# Altium schematic sheet PAGE3_POWER.SchDoc
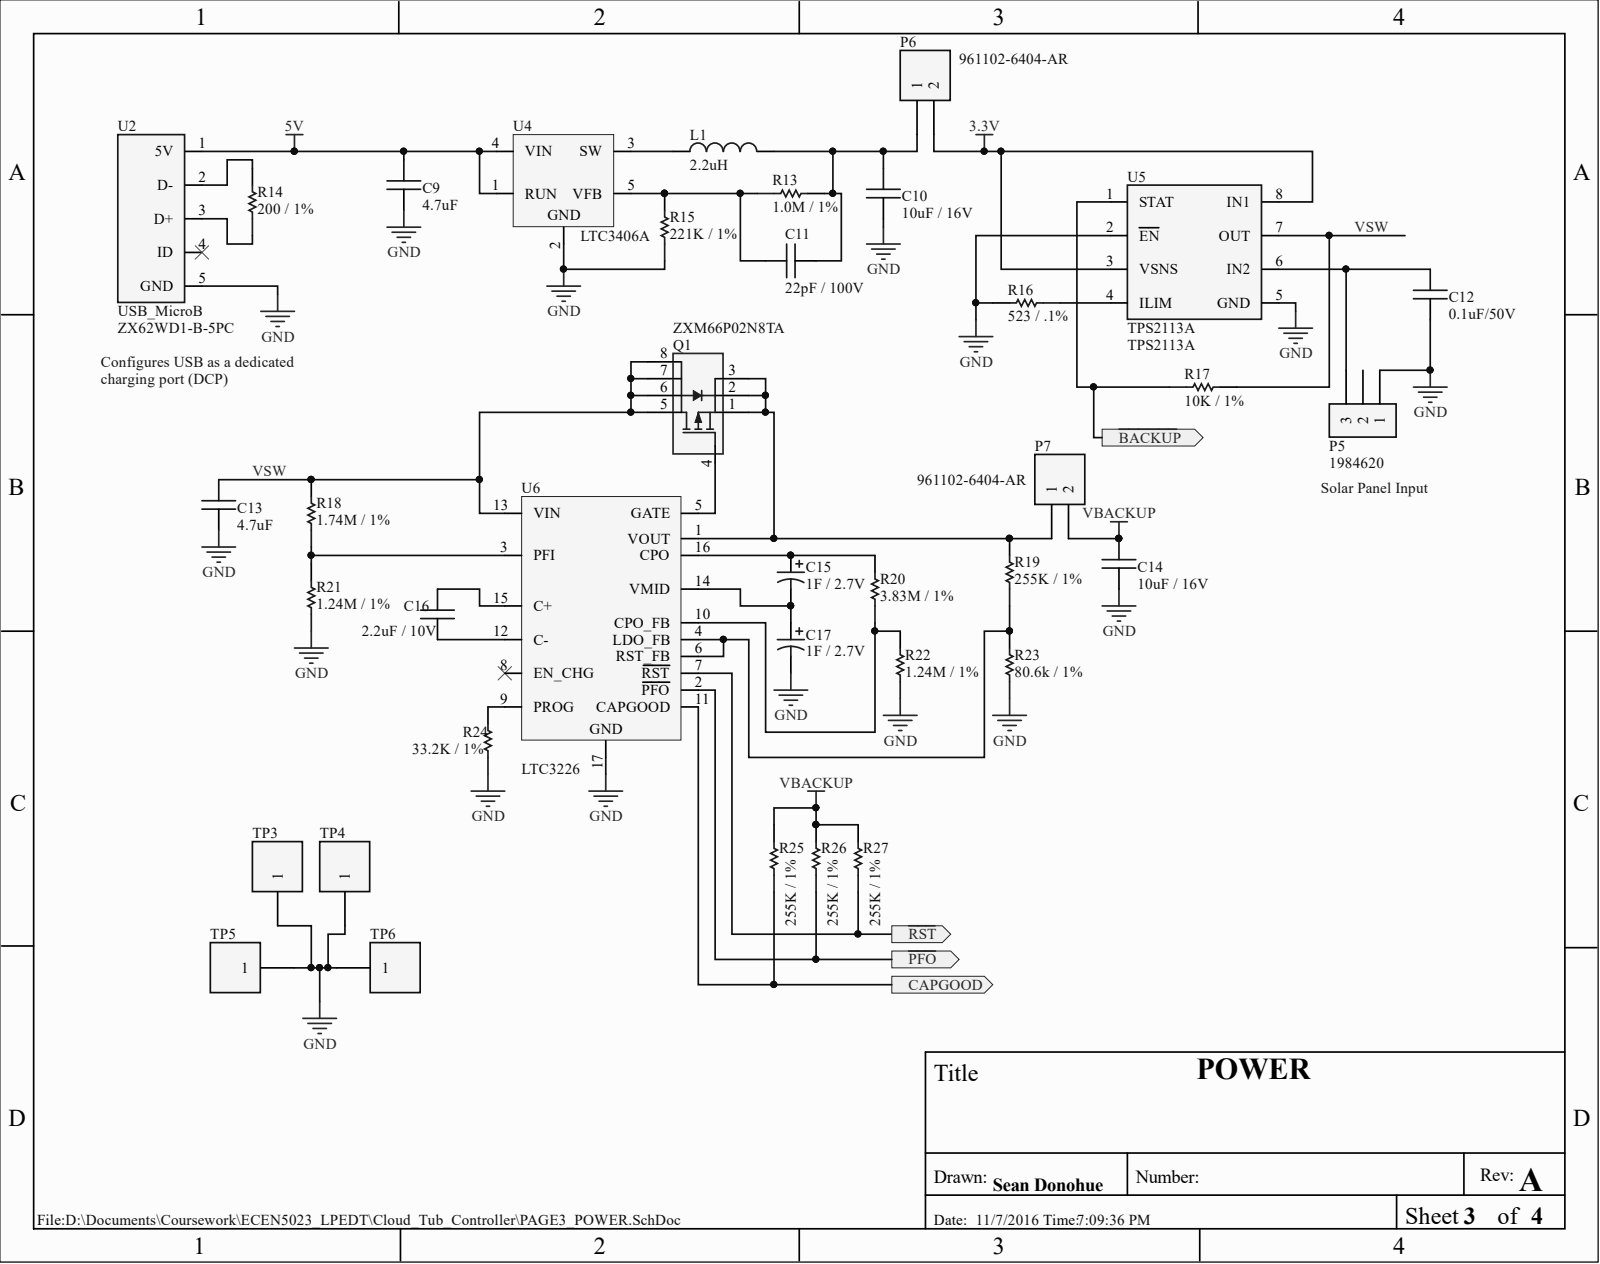
[diagram p0: PAGE3_POWER.SchDoc]

## Parts
C9  value 4.7uF  [0805]  (hidden comment: cap)
C10  value 10uF / 16V  [0603]  (hidden comment: cap)
C11  value 22pF / 100V  [0603]  (hidden comment: cap)
C12  value 0.1uF/50V  [0603]  (hidden comment: cap)
C13  value 4.7uF  [0805]  (hidden comment: cap)
C14  value 10uF / 16V  [0603]  (hidden comment: cap)
C15  value 1F / 2.7V  [Cap8x11_5]  (hidden comment: Cap Pol)
C16  value 2.2uF / 10V  [0603]  (hidden comment: cap)
C17  value 1F / 2.7V  [Cap8x11_5]  (hidden comment: Cap Pol)
L1  value 2.2uH  [LQH32C]  (hidden comment: Inductor)
P5  value 1984620  MfgPN: 1984620  [CONN_PHOENIX_3P_1984620]  (hidden comment: Header 3)
P6  value 961102-6404-AR  MfgPN: 961102-6404-AR  [HDR1X2]  (hidden comment: Header 2)
P7  value 961102-6404-AR  MfgPN: 961102-6404-AR  [HDR1X2]  (hidden comment: Header 2)
Q1  value ZXM66P02N8TA  MfgPN: ZXM66P02N8TA  [SO-8]  (hidden comment: PMOS_POWER-8)
R13  value 1.0M / 1%  [0603]  (hidden comment: Res)
R14  value 200 / 1%  [0603]  (hidden comment: Res)
R15  value 221K / 1%  [0603]  (hidden comment: Res)
R16  value 523 / .1%  [0603]  (hidden comment: Res)
R17  value 10K / 1%  [0603]  (hidden comment: Res)
R18  value 1.74M / 1%  [0603]  (hidden comment: Res)
R19  value 255K / 1%  [0603]  (hidden comment: Res)
R20  value 3.83M / 1%  [0603]  (hidden comment: Res)
R21  value 1.24M / 1%  [0603]  (hidden comment: Res)
R22  value 1.24M / 1%  [0603]  (hidden comment: Res)
R23  value 80.6k / 1%  [0603]  (hidden comment: Res)
R24  value 33.2K / 1%  [0603]  (hidden comment: Res)
R25  value 255K / 1%  [0603]  (hidden comment: Res)
R26  value 255K / 1%  [0603]  (hidden comment: Res)
R27  value 255K / 1%  [0603]  (hidden comment: Res)
TP3  Header 1  [HDR1]  (value not drawn)
TP4  Header 1  [HDR1]  (value not drawn)
TP5  Header 1  [HDR1]  (value not drawn)
TP6  Header 1  [HDR1]  (value not drawn)
U2  USB_MicroB  value ZX62WD1-B-5PC  [ZX62WD1-B-5PC]
U4  LTC3406A  [TSOT-23-5]
U5  TPS2113A  [TSSOP-8]
U6  LTC3226  [WFQFN-16]

## Ports
CAPGOOD, ~{BACKUP}, ~{PFO}, ~{RST}

## Nets
3.3V: P6.2 U5.3(VSNS) U5.8(IN1)
5V: C9.1 U2.1(5V) U4.1(RUN) U4.4(VIN)
GND: C9.2 C10.2 C12.2 C13.2 C14.2 C17.2 P5.1 R15.1 R16.2 R21.1 R22.1 R23.1 R24.1 TP3.1 TP4.1 TP5.1 TP6.1 U2.5(GND) U4.2 U5.2(EN) U5.5(GND) U6.17
VBACKUP: C14.1 P7.2 R25.2 R26.2 R27.2
~{BACKUP}: R17.1 U5.1(STAT) ; port ~{BACKUP}
~{PFO}: R26.1 U6.2(PFO) ; port ~{PFO}
~{RST}: R27.1 U6.7(RST) ; port ~{RST}
CAPGOOD: R25.1 U6.11(CAPGOOD) ; port CAPGOOD
VSW: C13.1 Q1.5 Q1.6 Q1.7 Q1.8 R17.2 R18.2 U5.7(OUT) U6.13(VIN)
NetC10_1: C10.1 C11.2 L1.2 P6.1 R13.2
NetC11_1: C11.1 R13.1 R15.2 U4.5(VFB)
NetC12_1: C12.1 P5.3 U5.6(IN2)
NetC15_1: C15.1 R20.2 U6.16(CPO)
NetC15_2: C15.2 C17.1 U6.14(VMID)
NetC16_1: C16.1 U6.15(C+)
NetC16_2: C16.2 U6.12(C-)
NetL1_1: L1.1 U4.3(SW)
NetP7_1: P7.1 Q1.1 Q1.2 Q1.3 R19.2 U6.1(VOUT)
NetQ1_4: Q1.4 U6.5(GATE)
NetR14_1: R14.1 U2.3(D+)
NetR14_2: R14.2 U2.2(D-)
NetR16_1: R16.1 U5.4(ILIM)
NetR18_1: R18.1 R21.2 U6.3(PFI)
NetR19_1: R19.1 R23.2 U6.4(LDO_FB) U6.6(RST_FB)
NetR20_1: R20.1 R22.2 U6.10(CPO_FB)
NetR24_2: R24.2 U6.9(PROG)

Not connected: P5 2; U2 4(ID); U6 8(EN_CHG)

## Library data of the parts
C9 C13 = ECC4702XR: CAP 4.7uF 16V X7R 0805; Database Table Name: CAP$; Mfg: Murata; MfgPN: GRM21BR71C475KA73L; Temp: '-55°C ~ 125°C; Unit Cost: 0.88; Vendor: Digikey; VendorPN: 490-4522-1; Component Kind: Standard; EetrexPN: ECC4702XR; Library Name: eetrex comp db.DbLib
C10 C14 = EXC142: CAP CER 10UF 16V X5R 0603; Database Table Name: CAP$; Mfg: Taiyo Uden; MfgPN: EMK107BBJ106MA-T; Temp: -55°C ~ 85°C; Unit Cost: 0.63; Vendor: Digkey; VendorPN: 587-3238-1-ND; Component Kind: Standard; EetrexPN: EXC142; Library Name: eetrex comp db.DbLib
C11 = EXC140: CAP CER 22PF 100V NP0 0603; Database Table Name: CAP$; Mfg: KEMET; MfgPN: C0603C220J1GACTU; Temp: -55°C ~ 125°C; Unit Cost: 0.1; Vendor: Digikey; VendorPN: 399-7874-1-ND; Component Kind: Standard; EetrexPN: EXC140; Library Name: eetrex comp db.DbLib
C12 = ECM1045XQ: CAP CER 0.1UF 50V 10% X7R 0603; Alt Mfg: TDK; Alt PN: C1608X7R1H104K; COST/10: 0.041; COST/50: 0.0222; COST/100: 0.0187; COST/500: 0.0131; Database Table Name: CAP$; Mfg: Murata; MfgPN: GRM188R71H104KA93D; Temp: -55°C ~ 125°C; Unit Cost: 0.1; Vendor: Digikey; VendorPN: 490-1519-1-ND; Component Kind: Standard; EetrexPN: ECM1045XQ; Library Name: eetrex comp db.DbLib
C15 C17 = EXC139: CAP 1F 2.7V THROUGH HOLE; Database Table Name: CAP$; Mfg: Maxwell; MfgPN: BCAP0001 P270 T01; Temp: -40°C ~ 85°C; Unit Cost: 2.3; Vendor: Digikey; VendorPN: 1182-1006-ND; Component Kind: Standard; EetrexPN: EXC139; Signal Integrity: Cap Pol; Simulation: CAP; Library Name: eetrex comp db.DbLib
C16 = EXC141: CAP CER 2.2UF 10V X7R 0603; Database Table Name: CAP$; Mfg: Samsung; MfgPN: CL10B225KP8NFNC; Temp: -55°C ~ 125°C; Unit Cost: 0.15; Vendor: Digikey; VendorPN: 1276-2016-1-ND; Component Kind: Standard; EetrexPN: EXC141; Library Name: eetrex comp db.DbLib
L1 = EL48: FIXED IND 2.2UH 790MA 97 MOHM; Database Table Name: IND$; Mfg: Murata; MfgPN: LQH32CN2R2M53L; Temp: -40°C ~ 85°C; Unit Cost: 0.35; Vendor: Digikey; VendorPN: 490-4056-1-ND; Component Kind: Standard; EetrexPN: EL48; Library Name: eetrex comp db.DbLib
P5 = EKP73: CONN TERM BLOCK T/H 3POS 3.5MM; CSA: YES; Database Table Name: CONN$; Mfg: Phoenix Contact; Unit Cost: 0.55; Vendor: Digikey; VendorPN: 277-1722-ND; Component Kind: Standard; EetrexPN: EKP73; Signal Integrity: Connector; UL: YES; Library Name: eetrex comp db.DbLib
P6 P7 = EKP117: CONN HEADER VERT SGL 2POS GOLD; Database Table Name: CONN$; Mfg: 3M; Unit Cost: 0.16; Vendor: Digikey; VendorPN: 3M9447-ND; Component Kind: Standard; EetrexPN: EKP117; Library Name: eetrex comp db.DbLib
Q1 = E333: MOSFET P-CH 20V 8A 8-SOIC; Database Table Name: IC$; Mfg: Diodes inc; Temp: -55°C ~ 150°C; Unit Cost: 2.61; Vendor: Digikey; VendorPN: ZXM66P02N8CT-ND; Component Kind: Standard; EetrexPN: E333; Library Name: eetrex comp db.DbLib
R13 = ER265: RES 1M OHM 1% 1/10W 0603 SMD; Database Table Name: RES$; Mfg: Panasonic; MfgPN: ERJ-3EKF1004V; Temp: -55°C ~ 155°C; Unit Cost: 0.1; Vendor: Digikey; VendorPN: P1.00MHCT-ND; Component Kind: Standard; EetrexPN: ER265; Signal Integrity: Res; Simulation: RESISTOR; Library Name: eetrex comp db.DbLib
R14 = ER264: RES 200 OHM 1% 1/10W 0603 SMD; Database Table Name: RES$; Mfg: Panasonic; MfgPN: ERJ-3EKF2000V; Temp: -55°C ~ 155°C; Unit Cost: 0.1; Vendor: Digikey; VendorPN: P200HCT-ND; Component Kind: Standard; EetrexPN: ER264; Signal Integrity: Res; Simulation: RESISTOR; Library Name: eetrex comp db.DbLib
R15 = ER266: RES 221K OHM 1% 1/10W 0603 SMD; Database Table Name: RES$; Mfg: Panasonic; MfgPN: ERJ-3EKF2213V; Temp: -55°C ~ 155°C; Unit Cost: 0.1; Vendor: Digikey; VendorPN: P221KHCT-ND; Component Kind: Standard; EetrexPN: ER266; Signal Integrity: Res; Simulation: RESISTOR; Library Name: eetrex comp db.DbLib
R16 = ERE5230BP: RES 523 OHM 1/10W .1% 0603 SMD; Alt Mfg: Panasonic; Alt PN: ERA-3AEB5230V; Database Table Name: RES$; Mfg: Susumu; MfgPN: RG1608P-5230-B-T5; Ref: ER149; Unit Cost: 0.204; Vendor: Digikey; VendorPN: RG16P523BCT-ND; Component Kind: Standard; EetrexPN: ERE5230BP; Signal Integrity: Res; Simulation: RESISTOR; Library Name: eetrex comp db.DbLib
R17 = ER275: RES 10K OHM 1% 1/8W 0603 SMD; Database Table Name: RES$; Mfg: Vishay; MfgPN: MCT06030C1002FP500; Temp: -55°C ~ 155°C; Unit Cost: 0.17; Vendor: Digikey; VendorPN: MCT0603-10.0K-CFCT-ND; Component Kind: Standard; EetrexPN: ER275; Signal Integrity: Res; Simulation: RESISTOR; Library Name: eetrex comp db.DbLib
R18 = ER268: RES 1.74M OHM 1% 1/10W 0603 SMD; Database Table Name: RES$; Mfg: Vishay; MfgPN: CRCW06031M74FKEA; Temp: -55°C ~ 155°C; Unit Cost: 0.1; Vendor: Digikey; VendorPN: 541-1.74MHCT-ND; Component Kind: Standard; EetrexPN: ER268; Signal Integrity: Res; Simulation: RESISTOR; Library Name: eetrex comp db.DbLib
R19 = ER270: RES 255K OHM 1% 1/10W 0603 SMD; Database Table Name: RES$; Mfg: Panasonic; MfgPN: ERJ-3EKF2553V; Temp: -55°C ~ 155°C; Unit Cost: 0.1; Value: 255K / 1%; Vendor: Digikey; VendorPN: P255KHCT-ND; Component Kind: Standard; EetrexPN: ER270; Signal Integrity: Res; Simulation: RESISTOR; Library Name: eetrex comp db.DbLib
R20 = ER272: RES 3.83M OHM 1% 1/10W 0603 SMD; Database Table Name: RES$; Mfg: Vishay; MfgPN: CRCW06033M83FKEA; Temp: -55°C ~ 155°C; Unit Cost: 0.1; Vendor: Digikey; VendorPN: 541-3.83MHCT-ND; Component Kind: Standard; EetrexPN: ER272; Signal Integrity: Res; Simulation: RESISTOR; Library Name: eetrex comp db.DbLib
R21 R22 = ER269: RES 1.24M OHM 1% 1/10W 0603 SMD; Database Table Name: RES$; Mfg: Vishay; MfgPN: CRCW06031M24FKEA; Temp: -55°C ~ 155°C; Unit Cost: 0.1; Value: 1.24M / 1%; Vendor: Digikey; VendorPN: 541-1.24MHCT-ND; Component Kind: Standard; EetrexPN: ER269; Signal Integrity: Res; Simulation: RESISTOR; Library Name: eetrex comp db.DbLib
R23 = ER271: RES 80.6K OHM 1% 1/10W 0603 SMD; Database Table Name: RES$; Mfg: Panasonic; MfgPN: ERJ-3EKF8062V; Temp: -55°C ~ 155°C; Unit Cost: 0.1; Vendor: Digikey; VendorPN: P80.6KHCT-ND; Component Kind: Standard; EetrexPN: ER271; Signal Integrity: Res; Simulation: RESISTOR; Library Name: eetrex comp db.DbLib
R24 = ER267: RES 33.2K OHM 1% 1/10W 0603 SMD; Database Table Name: RES$; Mfg: Panasonic; MfgPN: ERJ-3EKF3322V; Temp: -55°C ~ 155°C; Unit Cost: 0.1; Vendor: Digikey; VendorPN: P33.2KHCT-ND; Component Kind: Standard; EetrexPN: ER267; Signal Integrity: Res; Simulation: RESISTOR; Library Name: eetrex comp db.DbLib
R25-R27 = ER270: RES 255K OHM 1% 1/10W 0603 SMD; Database Table Name: RES$; Mfg: Panasonic; MfgPN: ERJ-3EKF2553V; Temp: -55°C ~ 155°C; Unit Cost: 0.1; Vendor: Digikey; VendorPN: P255KHCT-ND; Component Kind: Standard; EetrexPN: ER270; Signal Integrity: Res; Simulation: RESISTOR; Library Name: eetrex comp db.DbLib
TP3-TP6 = ekp17: CONN HEADER 1POS .330 tall, TIN; Database Table Name: CONN$; Mfg: Samtec; MfgPN: TSW-101-07-T-S; Unit Cost: 0.36; Value: HDR1; Vendor: Digikey; VendorPN: SAM1035-01-ND; Component Kind: Standard; EetrexPN: ekp17; Signal Integrity: Connector; Library Name: eetrex comp db.DbLib
U2 = EKP114: CONN RCPT MICRO USB B SPLASH PRF; Database Table Name: CONN$; Mfg: Hirose; Temp: -40°C ~ 85°C; Unit Cost: 2.00; Vendor: Digikey; VendorPN: H12193CT-ND; Component Kind: Standard; EetrexPN: EKP114; MfgPN: ZX62WD1-B-5PC; Library Name: eetrex comp db.DbLib
U4 = E332: IC REG BUCK ADJ 0.6A SYNC TSOT23; Database Table Name: IC$; Mfg: Linear Tech; MfgPN: LTC3406ABES5#TRMPBF; Temp: -40°C ~ 85°C; Unit Cost: 4.22; Vendor: Digikey; VendorPN: LTC3406ABES5#TRMPBFCT-ND; Component Kind: Standard; EetrexPN: E332; Value: LTC3406A; Library Name: eetrex comp db.DbLib
U5 = E331: IC OR CTRLR SRC SELECT 8TSSOP; Database Table Name: IC$; Mfg: Texas Instruments; MfgPN: TPS2113APWR; Temp: -40°C ~ 85°C; Unit Cost: 2.05; Vendor: Digikey; VendorPN: 296-16938-1-ND; Component Kind: Standard; EetrexPN: E331; Value: TPS2113A; Library Name: eetrex comp db.DbLib
U6 = E330: IC 2CELL SUPERCAP CHARGE 16QFN; Database Table Name: IC$; Mfg: Linear Tech; MfgPN: LTC3226EUD#PBF; Temp: -40°C ~ 125°C; Unit Cost: 5.7; Vendor: Digikey; VendorPN: LTC3226EUD#PBF-ND; Component Kind: Standard; EetrexPN: E330; Value: LTC3226; Library Name: eetrex comp db.DbLib
